# Altium schematic sheet MPU_Supply.SchDoc
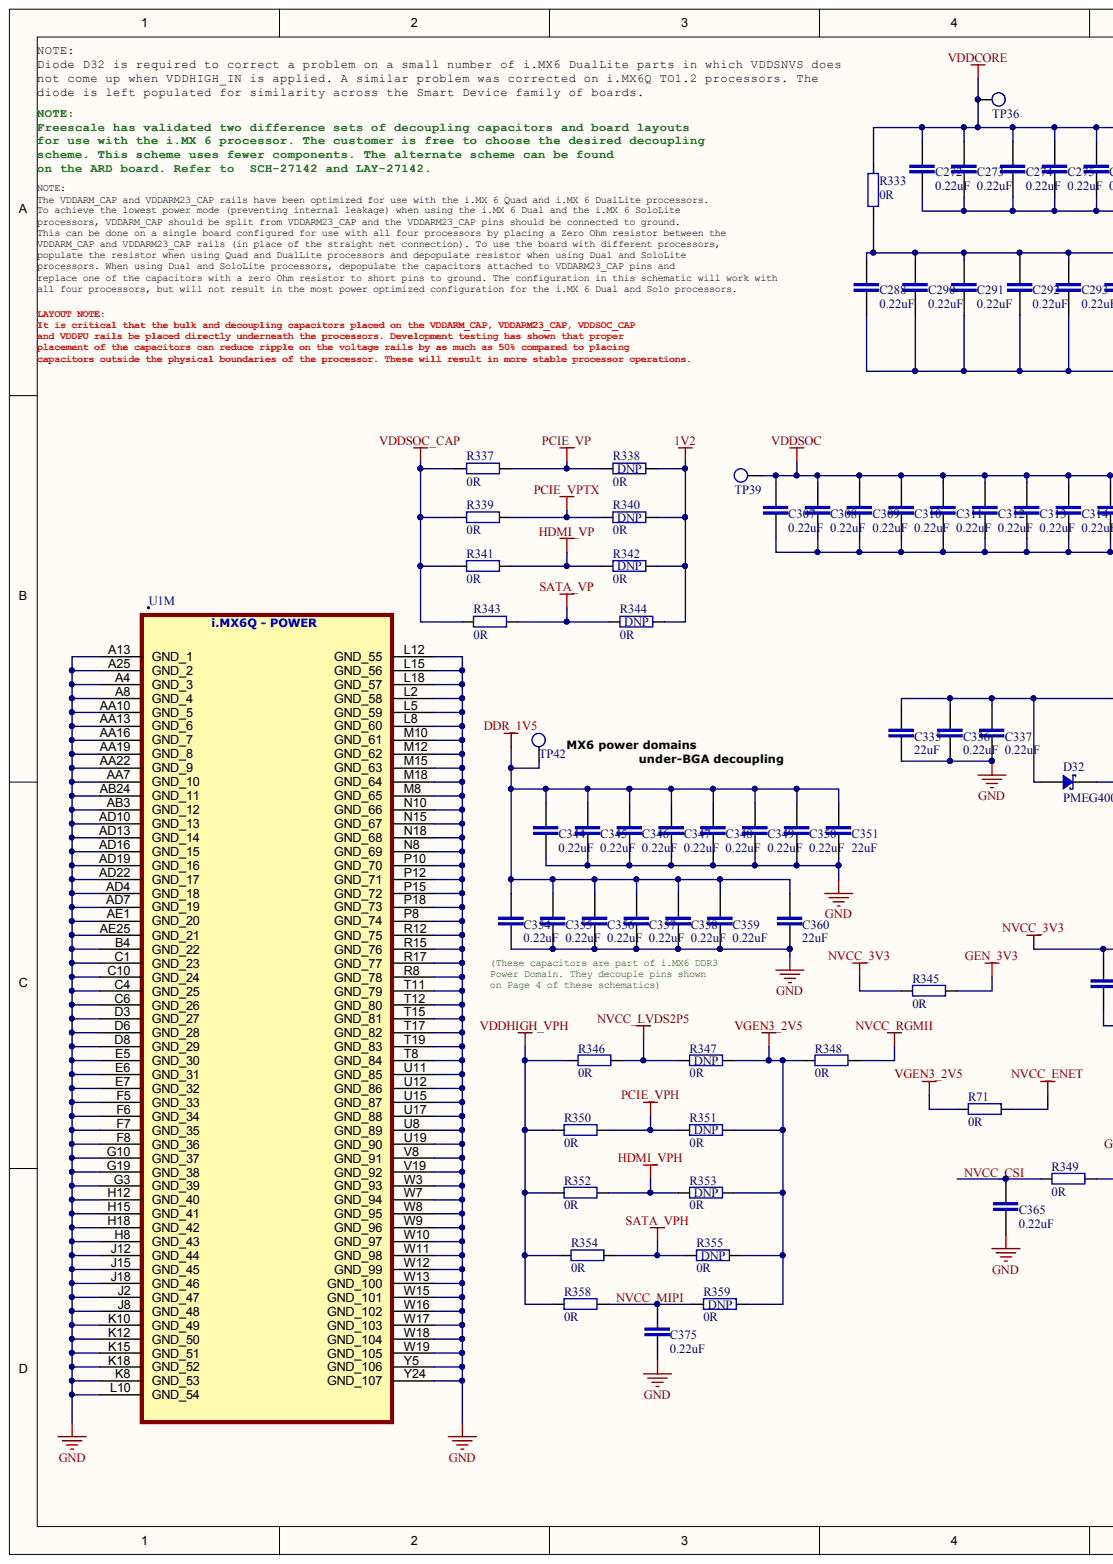
[diagram p0: MPU_Supply.SchDoc, left]
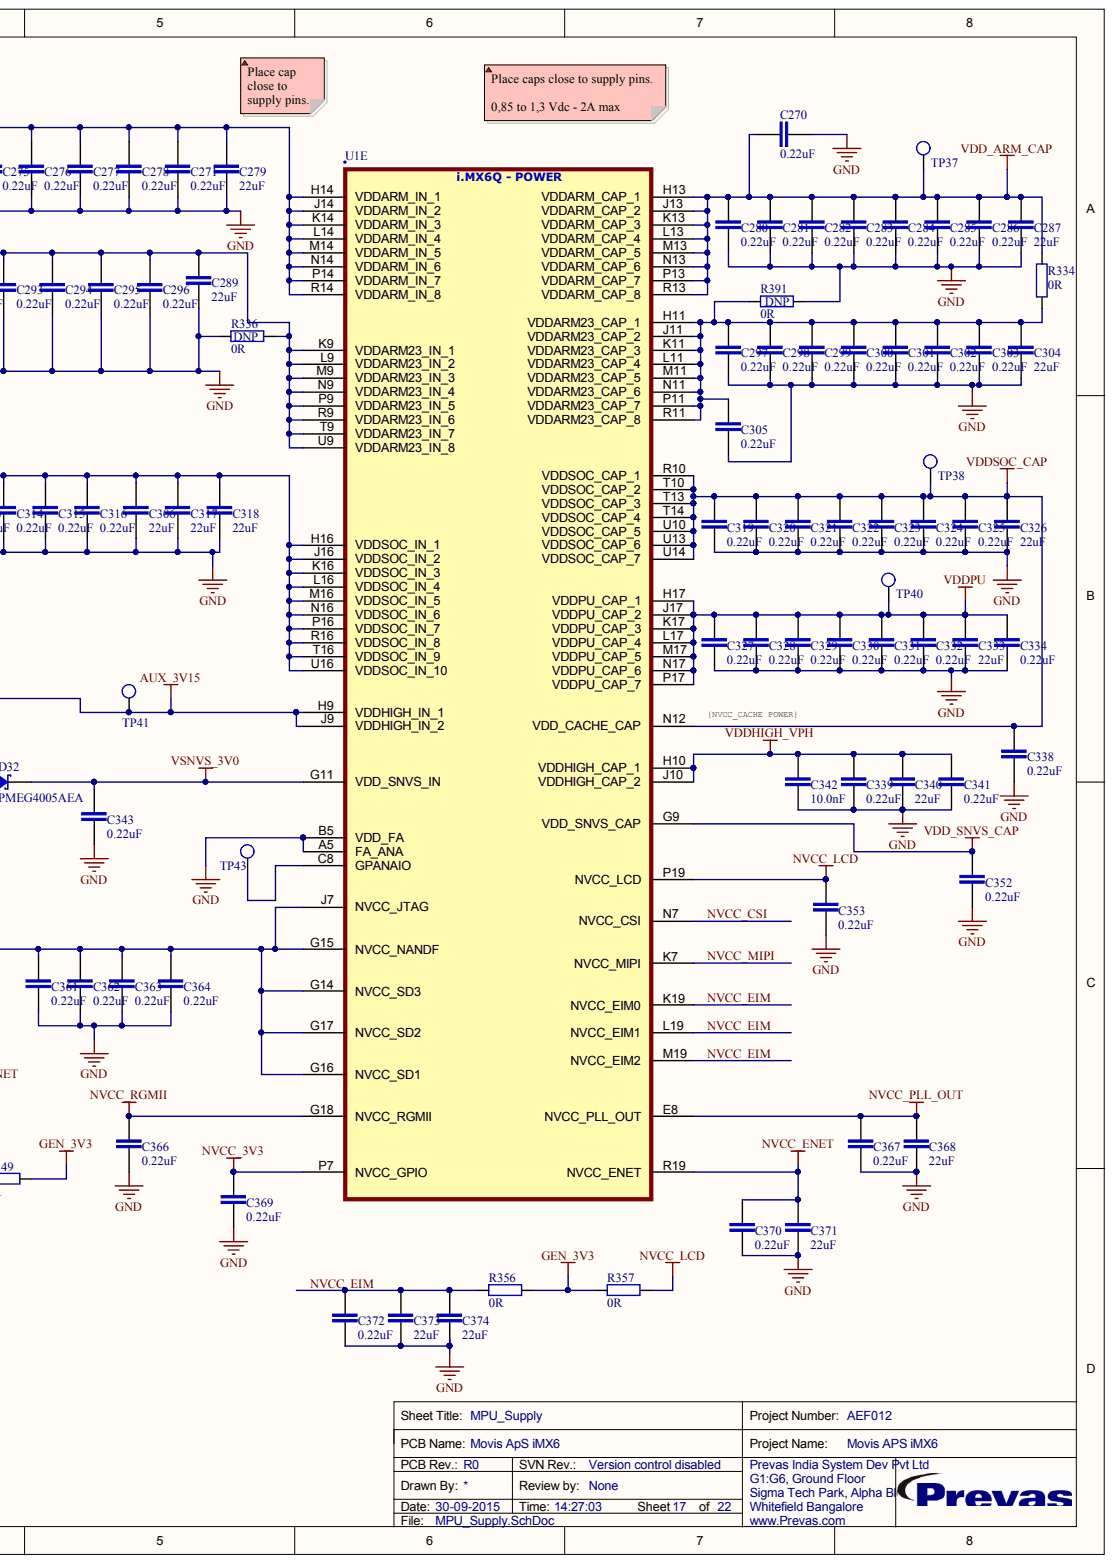
[diagram p1: MPU_Supply.SchDoc, right]

## Parts
C270  0.22uF  [CAPC0201X33NL]
C271  0.22uF  [CAPC0201X33NL]
C272  0.22uF  [CAPC0201X33NL]
C273  0.22uF  [CAPC0201X33NL]
C274  0.22uF  [CAPC0201X33NL]
C275  0.22uF  [CAPC0201X33NL]
C276  0.22uF  [CAPC0201X33NL]
C277  0.22uF  [CAPC0201X33NL]
C278  0.22uF  [CAPC0201X33NL]
C279  22uF  [CAPC3216X180N]
C280  0.22uF  [CAPC0201X33NL]
C281  0.22uF  [CAPC0201X33NL]
C282  0.22uF  [CAPC0201X33NL]
C283  0.22uF  [CAPC0201X33NL]
C284  0.22uF  [CAPC0201X33NL]
C285  0.22uF  [CAPC0201X33NL]
C286  0.22uF  [CAPC0201X33NL]
C287  22uF  [CAPC3216X180N]
C288  0.22uF  [CAPC0201X33NL]
C289  22uF  [CAPC3216X180N]
C290  0.22uF  [CAPC0201X33NL]
C291  0.22uF  [CAPC0201X33NL]
C292  0.22uF  [CAPC0201X33NL]
C293  0.22uF  [CAPC0201X33NL]
C294  0.22uF  [CAPC0201X33NL]
C295  0.22uF  [CAPC0201X33NL]
C296  0.22uF  [CAPC0201X33NL]
C297  0.22uF  [CAPC0201X33NL]
C298  0.22uF  [CAPC0201X33NL]
C299  0.22uF  [CAPC0201X33NL]
C300  0.22uF  [CAPC0201X33NL]
C301  0.22uF  [CAPC0201X33NL]
C302  0.22uF  [CAPC0201X33NL]
C303  0.22uF  [CAPC0201X33NL]
C304  22uF  [CAPC3216X180N]
C305  0.22uF  [CAPC0201X33NL]
C306  22uF  [CAPC3216X180N]
C307  0.22uF  [CAPC0201X33NL]
C308  0.22uF  [CAPC0201X33NL]
C309  0.22uF  [CAPC0201X33NL]
C310  0.22uF  [CAPC0201X33NL]
C311  0.22uF  [CAPC0201X33NL]
C312  0.22uF  [CAPC0201X33NL]
C313  0.22uF  [CAPC0201X33NL]
C314  0.22uF  [CAPC0201X33NL]
C315  0.22uF  [CAPC0201X33NL]
C316  0.22uF  [CAPC0201X33NL]
C317  22uF  [CAPC3216X180N]
C318  22uF  [CAPC3216X180N]
C319  0.22uF  [CAPC0201X33NL]
C320  0.22uF  [CAPC0201X33NL]
C321  0.22uF  [CAPC0201X33NL]
C322  0.22uF  [CAPC0201X33NL]
C323  0.22uF  [CAPC0201X33NL]
C324  0.22uF  [CAPC0201X33NL]
C325  0.22uF  [CAPC0201X33NL]
C326  22uF  [CAPC3216X180N]
C327  0.22uF  [CAPC0201X33NL]
C328  0.22uF  [CAPC0201X33NL]
C329  0.22uF  [CAPC0201X33NL]
C330  0.22uF  [CAPC0201X33NL]
C331  0.22uF  [CAPC0201X33NL]
C332  0.22uF  [CAPC0201X33NL]
C333  22uF  [CAPC3216X180N]
C334  0.22uF  [CAPC0201X33NL]
C335  22uF  [CAPC3216X180N]
C336  0.22uF  [CAPC0201X33NL]
C337  0.22uF  [CAPC0201X33NL]
C338  0.22uF  [CAPC0201X33NL]
C339  0.22uF  [CAPC0201X33NL]
C340  22uF  [CAPC3216X180N]
C341  0.22uF  [CAPC0201X33NL]
C342  10.0nF  [CAPC1005X55N]
C343  0.22uF  [CAPC0201X33NL]
C344  0.22uF  [CAPC0201X33NL]
C345  0.22uF  [CAPC0201X33NL]
C346  0.22uF  [CAPC0201X33NL]
C347  0.22uF  [CAPC0201X33NL]
C348  0.22uF  [CAPC0201X33NL]
C349  0.22uF  [CAPC0201X33NL]
C350  0.22uF  [CAPC0201X33NL]
C351  22uF  [CAPC3216X180N]
C352  0.22uF  [CAPC0201X33NL]
C353  0.22uF  [CAPC0201X33NL]
C354  0.22uF  [CAPC0201X33NL]
C355  0.22uF  [CAPC0201X33NL]
C356  0.22uF  [CAPC0201X33NL]
C357  0.22uF  [CAPC0201X33NL]
C358  0.22uF  [CAPC0201X33NL]
C359  0.22uF  [CAPC0201X33NL]
C360  22uF  [CAPC3216X180N]
C361  0.22uF  [CAPC0201X33NL]
C362  0.22uF  [CAPC0201X33NL]
C363  0.22uF  [CAPC0201X33NL]
C364  0.22uF  [CAPC0201X33NL]
C365  0.22uF  [CAPC0201X33NL]
C366  0.22uF  [CAPC0201X33NL]
C367  0.22uF  [CAPC0201X33NL]
C368  22uF  [CAPC3216X180N]
C369  0.22uF  [CAPC0201X33NL]
C370  0.22uF  [CAPC0201X33NL]
C371  22uF  [CAPC3216X180N]
C372  0.22uF  [CAPC0201X33NL]
C373  22uF  [CAPC3216X180N]
C374  22uF  [CAPC3216X180N]
C375  0.22uF  [CAPC0201X33NL]
D32  PMEG4005AEA  [SOD2512X110N]
R71  0R  [RESC0603X26N]
R333  0R  [RESC0603X26N]
R334  0R  [RESC0603X26N]
R336  0R  Fitted: DNP  [RESC0603X26N]
R337  0R  [RESC0603X26N]
R338  0R  Fitted: DNP  [RESC0603X26N]
R339  0R  [RESC0603X26N]
R340  0R  Fitted: DNP  [RESC0603X26N]
R341  0R  [RESC0603X26N]
R342  0R  Fitted: DNP  [RESC0603X26N]
R343  0R  [RESC0603X26N]
R344  0R  Fitted: DNP  [RESC0603X26N]
R345  0R  [RESC0603X26N]
R346  0R  [RESC0603X26N]
R347  0R  Fitted: DNP  [RESC0603X26N]
R348  0R  [RESC0603X26N]
R349  0R  [RESC0603X26N]
R350  0R  [RESC0603X26N]
R351  0R  Fitted: DNP  [RESC0603X26N]
R352  0R  [RESC0603X26N]
R353  0R  Fitted: DNP  [RESC0603X26N]
R354  0R  [RESC0603X26N]
R355  0R  Fitted: DNP  [RESC0603X26N]
R356  0R  [RESC0603X26N]
R357  0R  [RESC0603X26N]
R358  0R  [RESC0603X26N]
R359  0R  Fitted: DNP  [RESC0603X26N]
R391  0R  Fitted: DNP  [RESC0603X26N]
TP36  Testpoint  [TP_SMD]  (value not drawn)  (no BOM)
TP37  Testpoint  [TP_SMD]  (value not drawn)  (no BOM)
TP38  Testpoint  [TP_SMD]  (value not drawn)  (no BOM)
TP39  Testpoint  [TP_SMD]  (value not drawn)  (no BOM)
TP40  Testpoint  [TP_SMD]  (value not drawn)  (no BOM)
TP41  Testpoint  [TP_SMD]  (value not drawn)  (no BOM)
TP42  Testpoint  [TP_SMD]  (value not drawn)  (no BOM)
TP43  Testpoint  [TP_SMD]  (value not drawn)  (no BOM)
U1  MCIMX6Q6AVT10AC  [BGA624C80P25X25_2100X2100X160]  (value not drawn)  (parts E,M)

## Nets
1V2: R338.2 R340.2 R342.2 R344.2
AUX_3V15: C335.2 C336.2 C337.2 D32.A TP41.1 U1.H9(VDDHIGH_IN_1) U1.J9(VDDHIGH_IN_2)
DDR_1V5: C344.2 C345.2 C346.2 C347.2 C348.2 C349.2 C350.2 C351.2 C354.2 C355.2 C356.2 C357.2 C358.2 C359.2 C360.2 TP42.1
GEN_3V3: R345.2 R349.2 R356.2 R357.1
GND: C270.1 C271.1 C272.1 C273.1 C274.1 C275.1 C276.1 C277.1 C278.1 C279.1 C280.1 C281.1 C282.1 C283.1 C284.1 C285.1 C286.1 C287.1 C288.1 C289.1 C290.1 C291.1 C292.1 C293.1 C294.1 C295.1 C296.1 C297.1 C298.1 C299.1 C300.1 C301.1 C302.1 C303.1 C304.1 C305.1 C306.1 C307.1 C308.1 C309.1 C310.1 C311.1 C312.1 C313.1 C314.1 C315.1 C316.1 C317.1 C318.1 C319.1 C320.1 C321.1 C322.1 C323.1 C324.1 C325.1 C326.1 C327.1 C328.1 C329.1 C330.1 C331.1 C332.1 C333.1 C334.1 C335.1 C336.1 C337.1 C338.1 C339.1 C340.1 C341.1 C342.1 C343.1 C344.1 C345.1 C346.1 C347.1 C348.1 C349.1 C350.1 C351.1 C352.1 C353.1 C354.1 C355.1 C356.1 C357.1 C358.1 C359.1 C360.1 C361.1 C362.1 C363.1 C364.1 C365.1 C366.1 C367.1 C368.1 C369.1 C370.1 C371.1 C372.1 C373.1 C374.1 C375.1 R336.2 R391.1 U1.A4(GND_3) U1.A5(FA_ANA) U1.A8(GND_4) U1.A13(GND_1) U1.A25(GND_2) U1.AA7(GND_10) U1.AA10(GND_5) U1.AA13(GND_6) U1.AA16(GND_7) U1.AA19(GND_8) U1.AA22(GND_9) U1.AB3(GND_12) U1.AB24(GND_11) U1.AD4(GND_18) U1.AD7(GND_19) U1.AD10(GND_13) U1.AD13(GND_14) U1.AD16(GND_15) U1.AD19(GND_16) U1.AD22(GND_17) U1.AE1(GND_20) U1.AE25(GND_21) U1.B4(GND_22) U1.B5(VDD_FA) U1.C1(GND_23) U1.C4(GND_25) U1.C6(GND_26) U1.C10(GND_24) U1.D3(GND_27) U1.D6(GND_28) U1.D8(GND_29) U1.E5(GND_30) U1.E6(GND_31) U1.E7(GND_32) U1.F5(GND_33) U1.F6(GND_34) U1.F7(GND_35) U1.F8(GND_36) U1.G3(GND_39) U1.G10(GND_37) U1.G19(GND_38) U1.H8(GND_43) U1.H12(GND_40) U1.H15(GND_41) U1.H18(GND_42) U1.J2(GND_47) U1.J8(GND_48) U1.J12(GND_44) U1.J15(GND_45) U1.J18(GND_46) U1.K8(GND_53) U1.K10(GND_49) U1.K12(GND_50) U1.K15(GND_51) U1.K18(GND_52) U1.L2(GND_58) U1.L5(GND_59) U1.L8(GND_60) U1.L10(GND_54) U1.L12(GND_55) U1.L15(GND_56) U1.L18(GND_57) U1.M8(GND_65) U1.M10(GND_61) U1.M12(GND_62) U1.M15(GND_63) U1.M18(GND_64) U1.N8(GND_69) U1.N10(GND_66) U1.N15(GND_67) U1.N18(GND_68) U1.P8(GND_74) U1.P10(GND_70) U1.P12(GND_71) U1.P15(GND_72) U1.P18(GND_73) U1.R8(GND_78) U1.R12(GND_75) U1.R15(GND_76) U1.R17(GND_77) U1.T8(GND_84) U1.T11(GND_79) U1.T12(GND_80) U1.T15(GND_81) U1.T17(GND_82) U1.T19(GND_83) U1.U8(GND_89) U1.U11(GND_85) U1.U12(GND_86) U1.U15(GND_87) U1.U17(GND_88) U1.U19(GND_90) U1.V8(GND_91) U1.V19(GND_92) U1.W3(GND_93) U1.W7(GND_94) U1.W8(GND_95) U1.W9(GND_96) U1.W10(GND_97) U1.W11(GND_98) U1.W12(GND_99) U1.W13(GND_100) U1.W15(GND_101) U1.W16(GND_102) U1.W17(GND_103) U1.W18(GND_104) U1.W19(GND_105) U1.Y5(GND_106) U1.Y24(GND_107)
HDMI_VP: R341.2 R342.1
HDMI_VPH: R352.2 R353.1
NVCC_3V3: C361.2 C362.2 C363.2 C364.2 C369.2 R345.1 U1.G14(NVCC_SD3) U1.G15(NVCC_NANDF) U1.G16(NVCC_SD1) U1.G17(NVCC_SD2) U1.J7(NVCC_JTAG) U1.P7(NVCC_GPIO)
NVCC_ENET: C370.2 C371.2 R71.2 U1.R19(NVCC_ENET)
NVCC_LCD: C353.2 R357.2 U1.P19(NVCC_LCD)
NVCC_LVDS2P5: R346.2 R347.1
NVCC_PLL_OUT: C367.2 C368.2 U1.E8(NVCC_PLL_OUT)
NVCC_RGMII: C366.2 R348.2 U1.G18(NVCC_RGMII)
PCIE_VP: R337.2 R338.1
PCIE_VPH: R350.2 R351.1
PCIE_VPTX: R339.2 R340.1
SATA_VP: R343.2 R344.1
SATA_VPH: R354.2 R355.1
VDD_ARM_CAP: C270.2 C280.2 C281.2 C282.2 C283.2 C284.2 C285.2 C286.2 C287.2 R334.1 TP37.1 U1.H13(VDDARM_CAP_1) U1.J13(VDDARM_CAP_2) U1.K13(VDDARM_CAP_3) U1.L13(VDDARM_CAP_4) U1.M13(VDDARM_CAP_5) U1.N13(VDDARM_CAP_6) U1.P13(VDDARM_CAP_7) U1.R13(VDDARM_CAP_8)
VDD_SNVS_CAP: C352.2 U1.G9(VDD_SNVS_CAP)
VDDCORE: C271.2 C272.2 C273.2 C274.2 C275.2 C276.2 C277.2 C278.2 C279.2 R333.2 TP36.1 U1.H14(VDDARM_IN_1) U1.J14(VDDARM_IN_2) U1.K14(VDDARM_IN_3) U1.L14(VDDARM_IN_4) U1.M14(VDDARM_IN_5) U1.N14(VDDARM_IN_6) U1.P14(VDDARM_IN_7) U1.R14(VDDARM_IN_8)
VDDHIGH_VPH: C339.2 C340.2 C341.2 C342.2 R346.1 R350.1 R352.1 R354.1 R358.1 U1.H10(VDDHIGH_CAP_1) U1.J10(VDDHIGH_CAP_2)
VDDPU: C327.2 C328.2 C329.2 C330.2 C331.2 C332.2 C333.2 C334.2 TP40.1 U1.H17(VDDPU_CAP_1) U1.J17(VDDPU_CAP_2) U1.K17(VDDPU_CAP_3) U1.L17(VDDPU_CAP_4) U1.M17(VDDPU_CAP_5) U1.N17(VDDPU_CAP_6) U1.P17(VDDPU_CAP_7)
VDDSOC: C306.2 C307.2 C308.2 C309.2 C310.2 C311.2 C312.2 C313.2 C314.2 C315.2 C316.2 C317.2 C318.2 TP39.1 U1.H16(VDDSOC_IN_1) U1.J16(VDDSOC_IN_2) U1.K16(VDDSOC_IN_3) U1.L16(VDDSOC_IN_4) U1.M16(VDDSOC_IN_5) U1.N16(VDDSOC_IN_6) U1.P16(VDDSOC_IN_7) U1.R16(VDDSOC_IN_8) U1.T16(VDDSOC_IN_9) U1.U16(VDDSOC_IN_10)
VDDSOC_CAP: C319.2 C320.2 C321.2 C322.2 C323.2 C324.2 C325.2 C326.2 C338.2 R337.1 R339.1 R341.1 R343.1 TP38.1 U1.N12(VDD_CACHE_CAP) U1.R10(VDDSOC_CAP_1) U1.T10(VDDSOC_CAP_2) U1.T13(VDDSOC_CAP_3) U1.T14(VDDSOC_CAP_4) U1.U10(VDDSOC_CAP_5) U1.U13(VDDSOC_CAP_6) U1.U14(VDDSOC_CAP_7)
VGEN3_2V5: R71.1 R347.2 R348.1 R351.2 R353.2 R355.2 R359.2
VSNVS_3V0: C343.2 D32.C U1.G11(VDD_SNVS_IN)
NVCC_CSI: C365.2 R349.1 U1.N7(NVCC_CSI)
NVCC_EIM: C372.2 C373.2 C374.2 R356.1 U1.K19(NVCC_EIM0) U1.L19(NVCC_EIM1) U1.M19(NVCC_EIM2)
NVCC_MIPI: C375.2 R358.2 R359.1 U1.K7(NVCC_MIPI)
NetC288_2: C288.2 C289.2 C290.2 C291.2 C292.2 C293.2 C294.2 C295.2 C296.2 R333.1 R336.1 U1.K9(VDDARM23_IN_1) U1.L9(VDDARM23_IN_2) U1.M9(VDDARM23_IN_3) U1.N9(VDDARM23_IN_4) U1.P9(VDDARM23_IN_5) U1.R9(VDDARM23_IN_6) U1.T9(VDDARM23_IN_7) U1.U9(VDDARM23_IN_8)
NetC297_2: C297.2 C298.2 C299.2 C300.2 C301.2 C302.2 C303.2 C304.2 C305.2 R334.2 R391.2 U1.H11(VDDARM23_CAP_1) U1.J11(VDDARM23_CAP_2) U1.K11(VDDARM23_CAP_3) U1.L11(VDDARM23_CAP_4) U1.M11(VDDARM23_CAP_5) U1.N11(VDDARM23_CAP_6) U1.P11(VDDARM23_CAP_7) U1.R11(VDDARM23_CAP_8)
NetTP43_1: TP43.1 U1.C8(GPANAIO)

## Library data of the parts
C270-C278 C280-C286 C288 C290-C303 C305 C307-C316 C319-C325 C327-C332 C334 C336-C339 C341 C343-C350 C352-C359 C361-C367 C369 C370 C372 C375 = INNISI-97: CAP CER 0.22UF 10V 10% X5R 0201; ref_part_type: /capacitor/non_polarized/ceramic/; source_manufacturer_1: Murata; source_manufacturer_1_pn: GRM033R61A224KE90D; cae_altium_pcb_status: Prototype; Database Table Name: altium_default; db_rev: 7699; elec_temp_range: -55°C ~ 85°C; phy_height: 0.33mm; phy_mounting: SMD; phy_packaging_type: 0201; ref_datasheet: INNISI-86; ref_keyword: Multilayer Chip Capacitor; cae_altium_sch_status: Prototype; Component Kind: Standard; ComponentLink1Description: Datasheet; ComponentLink1URL: https://cop201.prevas.dk/viewvc/datasheet/INNISI-86.pdf?root=hw_components&view=co; db_created: 2015-08-03 09:38:06.0; db_last_updated: 07-08-2015 07:23:14; db_local_id: INNISI-97; db_status: Prototype; elec_tolerance: 10%; elec_value: 0.22uF; elec_voltage_rating: 10V; phy_material: X5R; Library Name: altium_mysql.dblib
C279 C287 C289 C304 C306 C317 C318 C326 C333 C335 C340 C351 C360 C368 C371 C373 C374 = INNISI-77: CAP CER 22UF 25V 10% X5R 1206; ref_part_type: /capacitor/non_polarized/ceramic/; source_manufacturer_1: Murata Electronics; source_manufacturer_1_pn: GRM31CR61E226KE15L; cae_altium_pcb_status: Prototype; Database Table Name: altium_default; db_rev: 7573; elec_temp_range: -55 to +85 C; phy_height: 1.8mm; phy_mounting: SMD; phy_packaging_type: 1206; ref_datasheet: INNISI-74; ref_keyword: Multilayer chip capacitor; cae_altium_sch_status: Prototype; Component Kind: Standard; ComponentLink1Description: Datasheet; ComponentLink1URL: https://cop201.prevas.dk/viewvc/datasheet/INNISI-74.pdf?root=hw_components&view=co; db_created: 2015-08-03 04:56:21.0; db_last_updated: 03-08-2015 04:56:22; db_local_id: INNISI-77; db_status: Prototype; elec_tolerance: 10%; elec_value: 22uF; elec_voltage_rating: 25V; phy_material: X5R; Library Name: altium_mysql.dblib
C342 = INNISI-82: CAP CER 10nF 50V 10% X7R 0402; ref_part_type: /capacitor/non_polarized/ceramic/; source_manufacturer_1: Yageo; source_manufacturer_1_pn: CC0402KRX7R9BB103; cae_altium_pcb_status: Prototype; Database Table Name: altium_default; db_rev: 7579; elec_temp_range: -55 to +125C; phy_height: 0.55mm; phy_mounting: SMD; phy_packaging_type: 0402; ref_datasheet: INNISI-72; ref_keyword: Ceramic capacitor; cae_altium_sch_status: Prototype; Component Kind: Standard; ComponentLink1Description: Datasheet; ComponentLink1URL: https://cop201.prevas.dk/viewvc/datasheet/INNISI-72.pdf?root=hw_components&view=co; db_created: 2015-08-03 05:52:48.0; db_last_updated: 03-08-2015 05:52:50; db_local_id: INNISI-82; db_status: Prototype; elec_tolerance: 10%; elec_value: 10.0nF; elec_voltage_rating: 50V; phy_material: X7R; Library Name: altium_mysql.dblib
D32 = MASD-133: Diode Very low VF SOD323; ref_part_type: /diode/schottky/; source_manufacturer_1: Philips; cae_altium_pcb_status: Prototype; Database Table Name: altium_default; db_rev: 2165; elec_current_rating: 500mA; elec_temp_range: -65 to +150; elec_voltage_rating: 40V; phy_height: 1.1mm; phy_mounting: SMD; ref_datasheet: MASD-123; ref_keyword: Schottky Diode SMD; cae_altium_sch_status: Prototype; Component Kind: Standard; ComponentLink1Description: Datasheet; ComponentLink1URL: https://cop201.prevas.dk/viewvc/datasheet/MASD-123.pdf?root=hw_components&view=co; db_created: 2009-05-13 10:24:57; db_last_updated: 01-03-2010 14:47:58; db_local_id: MASD-133; db_status: Prototype; elec_value: PMEG4005AEA; phy_packaging_type: SOD323; source_manufacturer_1_pn: PMEG4005AEA; Library Name: altium_mysql.dblib
R71 R333 R334 R336-R359 R391 = JEPE-266: Jumper 0201; ref_part_type: /resistor/fixed/; source_manufacturer_1: Panasonic; source_manufacturer_1_pn: ERJ-1GE0R00C; cae_altium_pcb_status: Prototype; Database Table Name: altium_default; db_rev: 2233; elec_power_rating: 0.05W; elec_temp_range: -55 to +125C; elec_voltage_rating: 25V; phy_height: 0.26mm; phy_material: Thick Film; phy_mounting: SMD; phy_packaging_type: 0201; ref_datasheet: JEPE-147; ref_keyword: Thick film chip jumper; cae_altium_sch_status: Prototype; Component Kind: Standard; ComponentLink1Description: Datasheet; ComponentLink1URL: https://cop201.prevas.dk/viewvc/datasheet/JEPE-147.pdf?root=hw_components&view=co; db_created: 2009-10-26 17:55:39; db_last_updated: 26-04-2010 14:46:09; db_local_id: JEPE-266; db_status: Prototype; elec_value: 0R; Library Name: altium_mysql.dblib
TP36-TP43 = JEPE-114: Test Point SMD; ref_part_type: /pcb_item/; cae_altium_pcb_status: Prototype; Database Table Name: altium_default; db_rev: 3281; cae_altium_sch_status: Prototype; ComponentLink1Description: Datasheet; db_created: 2008-08-18 11:39:42; db_last_updated: 23-03-2011 14:14:06; db_local_id: JEPE-114; db_status: Prototype; elec_value: Test Point; phy_mounting: SMD; ref_keyword: Test  Point; Library Name: altium_mysql.dblib
U1 = INRAAN-5: i.MX 6Dual/6Quad; ref_part_type: /integrated/digital/processor/general/; AGILE_PN: 344-01218; CAD_APPROVED: Yes; cae_altium_pcb_status: Prototype; CLASS: IC; Database Table Name: altium_default; db_rev: 6247; elec_temp_range: -40 to +105; elec_voltage_rating: 1.5V; MFG_NAME01: FREESCALE SEMICONDUCTOR; phy_height: 1.85; phy_mounting: SMD; phy_packaging_type: FCPBGA96_624; ref_datasheet: INRAAN-6; cae_altium_sch_status: Prototype; Component Kind: Standard; ComponentLink1Description: Datasheet; ComponentLink1URL: https://cop201.prevas.dk/viewvc/datasheet/INRAAN-6.pdf?root=hw_components&view=co; db_created: 2013-10-21 12:25:57.0; db_last_updated: 27-12-2013 09:32:57; db_local_id: INRAAN-5; db_status: Prototype; elec_value: MCIMX6Q6AVT10AC; HEIGHT: 2.16MM; MFG_PN01: MCIMX6Q6AVT10AC; ref_keyword: i.MX 6Dual/6Quad; ROHS: Yes; source_manufacturer_1: Freescale Semiconductor; source_manufacturer_1_pn: MCIMX6Q6AVT10AC; Library Name: altium_mysql.dblib
